# Altium schematic sheet Sheet1.SchDoc
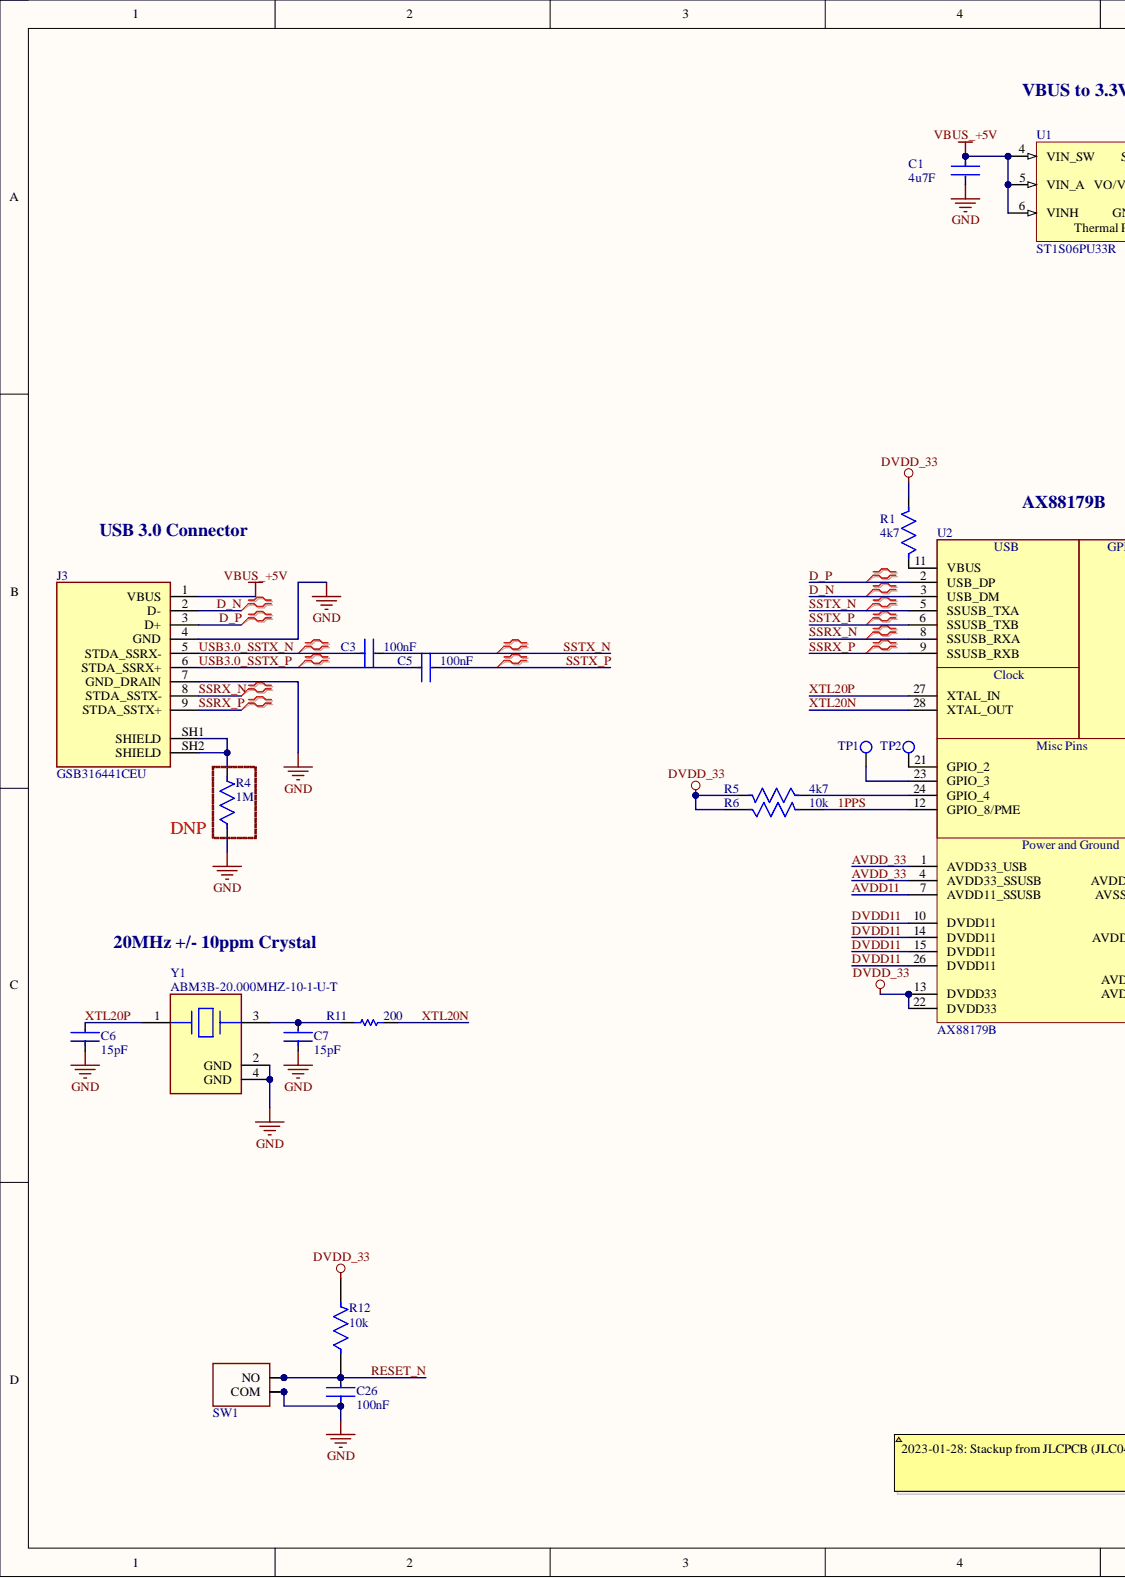
[diagram p0: Sheet1.SchDoc, left]
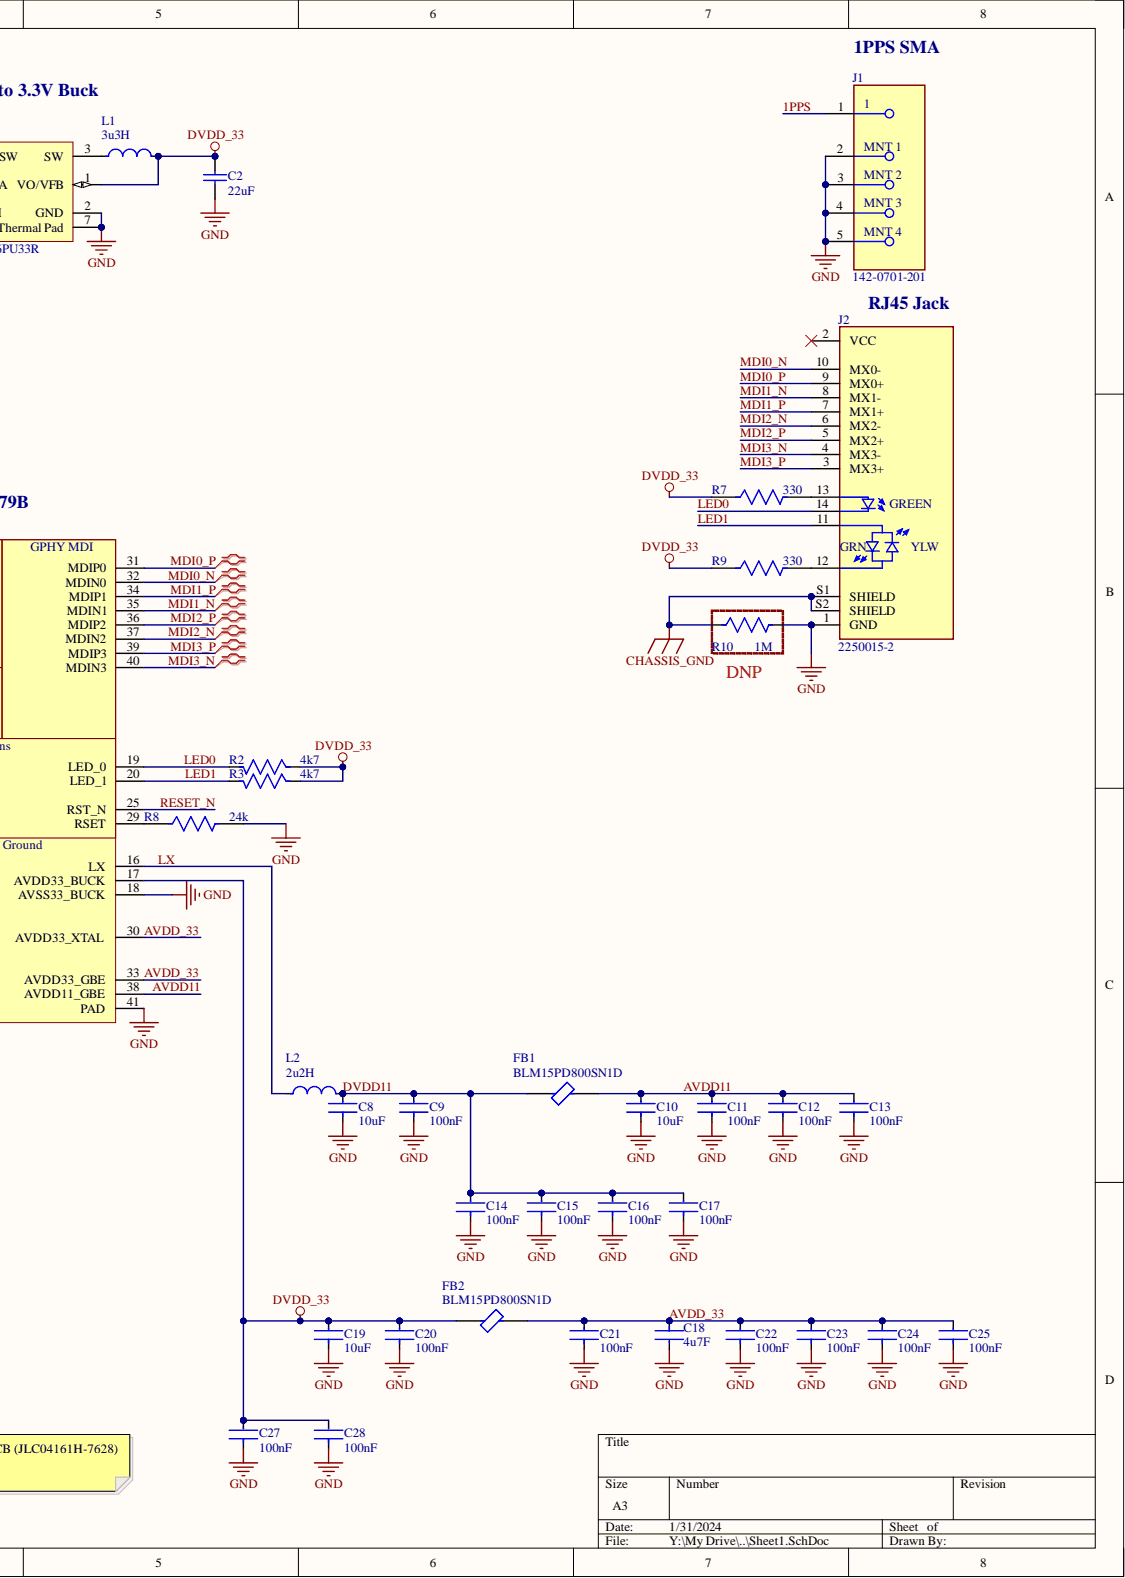
[diagram p1: Sheet1.SchDoc, right]

## Parts
C1  4u7F  [FP-0402-L_1_0_0_15-W_0_5-IPC_C]
C2  22uF  [CAPC1608X100X20ML10]
C3  100nF  [FP-CL251-IPC_C]
C5  100nF  [FP-CL251-IPC_C]
C6  15pF  [FP-CBR04C-MFG]
C7  15pF  [FP-CBR04C-MFG]
C8  10uF  [FP-CL05A106MP5NUNC-MFG]
C9  100nF  [FP-CL251-IPC_C]
C10  10uF  [FP-CL05A106MP5NUNC-MFG]
C11  100nF  [FP-CL251-IPC_C]
C12  100nF  [FP-CL251-IPC_C]
C13  100nF  [FP-CL251-IPC_C]
C14  100nF  [FP-CL251-IPC_C]
C15  100nF  [FP-CL251-IPC_C]
C16  100nF  [FP-CL251-IPC_C]
C17  100nF  [FP-CL251-IPC_C]
C18  4u7F  [FP-0402-L_1_0_0_15-W_0_5-IPC_C]
C19  10uF  [FP-CL05A106MP5NUNC-MFG]
C20  100nF  [FP-CL251-IPC_C]
C21  100nF  [FP-CL251-IPC_C]
C22  100nF  [FP-CL251-IPC_C]
C23  100nF  [FP-CL251-IPC_C]
C24  100nF  [FP-CL251-IPC_C]
C25  100nF  [FP-CL251-IPC_C]
C26  100nF  [FP-CL251-IPC_C]
C27  100nF  [FP-CL251-IPC_C]
C28  100nF  [FP-CL251-IPC_C]
FB1  BLM15PD800SN1D  [FP-BLM15-0_05-MFG]
FB2  BLM15PD800SN1D  [FP-BLM15-0_05-MFG]
J1  142-0701-201  Case/Package: SMA  [FP-142-0701-201-MFG]
J2  2250015-2  Connector Type: RJ45  [CONN_2250015-2]
J3  GSB316441CEU  [CONN_AMPHENOL_GSB316441CEU]
L1  3u3H  [FP-LQM2HPN_G0-IPC_A]
L2  2u2H  [FP-MLZ2012-0_85-IPC_A]
R1  4k7  [FP-RT0402-MFG]
R2  4k7  [FP-RT0402-MFG]
R3  4k7  [FP-RT0402-MFG]
R4  1M  [FP-RMCF0402-MFG]  (no BOM)
R5  4k7  [FP-RT0402-MFG]
R6  10k  [FP-RMCF0402-IPC_C]
R7  330  [FP-RC1005-IPC_B]
R8  24k  [FP-RT0402-MFG]
R9  330  [FP-RC1005-IPC_B]
R10  1M  [FP-RMCF0402-MFG]  (no BOM)
R11  200  [RESC1005X40X25LL10T10]
R12  10k  [FP-RMCF0402-IPC_C]
SW1  SW_PTS815_SJG_250_SMTR_LFS  [SW_PTS815SJG250SMTRLFS]  (value not drawn)
TP1  Test Point 1MM  [TP_PAD-1MM]  (value not drawn)  (no BOM)
TP2  Test Point 1MM  [TP_PAD-1MM]  (value not drawn)  (no BOM)
U1  ST1S06PU33R  [DFN6D_L]
U2  AX88179B  [IC_AX88179B_QFN40_5X5]
Y1  ABM3B-20.000MHZ-10-1-U-T  [FP-ABM3B-MFG]

## Nets
CHASSIS_GND: J2.S1(SHIELD) J2.S2(SHIELD) R10.1
DVDD_33: C2.2 C19.2 C20.2 C27.2 C28.2 FB2.1 L1.2 R1.2 R2.1 R3.1 R5.2 R6.1 R7.1 R9.1 R12.2 U1.1(VO/VFB) U2.13(DVDD33) U2.17(AVDD33_BUCK) U2.22(DVDD33)
GND: C1.1 C2.1 C6.2 C7.2 C8.1 C9.1 C10.1 C11.1 C12.1 C13.1 C14.1 C15.1 C16.1 C17.1 C18.1 C19.1 C20.1 C21.1 C22.1 C23.1 C24.1 C25.1 C26.1 C27.1 C28.1 J1.2 J1.3 J1.4 J1.5 J2.1(GND) J3.4(GND) J3.7(GND_DRAIN) R4.1 R8.2 R10.2 SW1.1(COM) SW1.2 U1.2(GND) U1.7(Thermal_Pad) U2.18(AVSS33_BUCK) U2.41(PAD) Y1.2(GND) Y1.4(GND)
VBUS_+5V: C1.2 J3.1(VBUS) U1.4(VIN_SW) U1.5(VIN_A) U1.6(VINH)
1PPS: J1.1 R6.2 U2.12(GPIO_8/PME)
AVDD11: C10.2 C11.2 C12.2 C13.2 FB1.2 U2.7(AVDD11_SSUSB) U2.38(AVDD11_GBE)
AVDD_33: C18.2 C21.2 C22.2 C23.2 C24.2 C25.2 FB2.2 U2.1(AVDD33_USB) U2.4(AVDD33_SSUSB) U2.30(AVDD33_XTAL) U2.33(AVDD33_GBE)
D_N: J3.2(D-) U2.3(USB_DM)
D_P: J3.3(D+) U2.2(USB_DP)
DVDD11: C8.2 C9.2 C14.2 C15.2 C16.2 C17.2 FB1.1 L2.2 U2.10(DVDD11) U2.14(DVDD11) U2.15(DVDD11) U2.26(DVDD11)
LED0: J2.14 R2.2 U2.19(LED_0)
LED1: J2.11 R3.2 U2.20(LED_1)
LX: L2.1 U2.16(LX)
MDI0_N: J2.10(MX0-) U2.32(MDIN0)
MDI0_P: J2.9(MX0+) U2.31(MDIP0)
MDI1_N: J2.8(MX1-) U2.35(MDIN1)
MDI1_P: J2.7(MX1+) U2.34(MDIP1)
MDI2_N: J2.6(MX2-) U2.37(MDIN2)
MDI2_P: J2.5(MX2+) U2.36(MDIP2)
MDI3_N: J2.4(MX3-) U2.40(MDIN3)
MDI3_P: J2.3(MX3+) U2.39(MDIP3)
RESET_N: C26.2 R12.1 SW1.3(NO) SW1.4 U2.25(RST_N)
SSRX_N: J3.8(STDA_SSTX-) U2.8(SSUSB_RXA)
SSRX_P: J3.9(STDA_SSTX+) U2.9(SSUSB_RXB)
SSTX_N: C3.2 U2.5(SSUSB_TXA)
SSTX_P: C5.2 U2.6(SSUSB_TXB)
USB3.0_SSTX_N: C3.1 J3.5(STDA_SSRX-)
USB3.0_SSTX_P: C5.1 J3.6(STDA_SSRX+)
XTL20N: R11.2 U2.28(XTAL_OUT)
XTL20P: C6.1 U2.27(XTAL_IN) Y1.1
NetC7_1: C7.1 R11.1 Y1.3
NetJ2_12: J2.12 R9.2
NetJ2_13: J2.13 R7.2
NetJ3_SH1: J3.SH1(SHIELD) J3.SH2(SHIELD) R4.2
NetL1_1: L1.1 U1.3(SW)
NetR1_1: R1.1 U2.11(VBUS)
NetR5_1: R5.1 U2.24(GPIO_4)
NetR8_1: R8.1 U2.29(RSET)
NetTP1_1: TP1.1 U2.23(GPIO_3)
NetTP2_1: TP2.1 U2.21(GPIO_2)

Not connected: J2 2(VCC)

## Library data of the parts
C1 C18 = CMP-14477-004104-2: Ceramic Capacitor General Use 4.7uF ±20% 10V X5R 0402; Manufacturer: Taiyo Yuden; Manufacturer Part Number: LMK105BBJ475MVLF; Material: 4241ConductorMaterial; Capacitance: 4.7uF; Case Code (Imperial): 0402; Case Code (Metric): 1005; Case/Package: 0402; Category: Ceramic Capacitors; Component Kind: Standard; ComponentLink1Description: https://datasheet.ciiva.com/24462/423lmk105bbj475mvlf-24462535.pdf?src-supplier=Onlinecomponents.com; ComponentLink1URL: https://datasheet.ciiva.com/24462/423lmk105bbj475mvlf-24462535.pdf?src-supplier=Onlinecomponents.com; ComponentLink2Description: https://datasheet.ciiva.com/18697/mlcc-all-e-18697686.pdf?src-supplier=Digikey; ComponentLink2URL: https://datasheet.ciiva.com/18697/mlcc-all-e-18697686.pdf?src-supplier=Digikey; ComponentLink3Description: https://datasheet.ciiva.com/18697/mlcc-all-e-18697685.pdf?src-supplier=Digikey; ComponentLink3URL: https://datasheet.ciiva.com/18697/mlcc-all-e-18697685.pdf?src-supplier=Digikey; Construction: Flat; Dielectric: X5R; Halogen Free: Halogen Free; Height: 0.5mm; Length: 1mm; Library Name: Altium Content Vault; Max Operating Temperature: 85°C; Min Operating Temperature: -55°C; Mount: Surface Mount; Mounting Technology: Surface Mount; Package Quantity: 10000; Packaging: Tape and Reel; Pricing: 1=0.19, 10=0.12, 50=0.076, 100=0.0607, 500=0.04386, 1000=0.03879, 2500=0.03711, 5000=0.03373 (USD); Resistor Type: Ceramic; RoHS: Yes; RoHS Compliant: Yes; Series: M; Stock: 753964; Supplier: Digikey; Supplier Part Number: 587-3408-1-ND; Termination: SMD/SMT; Thickness: 0.022inch; Tolerance: 20%; Value: 4.7uF; Voltage Rating: 10V; Voltage Rating (DC): 10V; Weight: 2.3E-05oz; Width: 0.02inch
C2 = CMP-2000-05441-1: Chip Capacitor, 22 uF, +/- 20%, 10 V, -55 to 85 degC, 0603 (1608 Metric), RoHS, Tape and Reel; Manufacturer: TDK; Manufacturer Part Number: C1608X5R1A226M080AC; PCN Part Number: MLCCPartNumberChange30/Nov/2012; Capacitance: 22µF; Design Resources: 20WNon-IsolatedBuckLEDDriverUsingPLLNK460KG; Dielectric: X5R; Dielectric Material: Ceramic; Kits: 445-9014-KIT-ND-KITMLCC100PF-22UF060310-50V; Material: 4241ConductorMaterial; Online Catalog: CSeries,GeneralPurpose; Other Names: 445-9077-1; Product Training Modules: CSeriesGeneralApplicationsSEAT,CCV,andTVCLDesignToolsGuidetoReplacinganElectrolyticCapacitorwithanMLCC; Voltage - Rated: 10V; Applications: GeneralPurpose; Case Code (Imperial): 0603; Case Code (Metric): 1608; Case/Package: 0603; Category: Capacitors; Component Kind: Standard; ComponentLink1Description: https://datasheet.ciiva.com/6274/tdkmlcccaprange-6274860.pdf?src-supplier=Digikey; ComponentLink1URL: https://datasheet.ciiva.com/6274/tdkmlcccaprange-6274860.pdf?src-supplier=Digikey; ComponentLink2Description: https://datasheet.ciiva.com/6274/tdkmlcccaprange-6274859.pdf?src-supplier=Digikey; ComponentLink2URL: https://datasheet.ciiva.com/6274/tdkmlcccaprange-6274859.pdf?src-supplier=Digikey; ComponentLink3Description: https://datasheet.ciiva.com/6274/tdkmlcccaprange-6274861.pdf?src-supplier=Digikey; ComponentLink3URL: https://datasheet.ciiva.com/6274/tdkmlcccaprange-6274861.pdf?src-supplier=Digikey; ComponentLink4Description: https://datasheet.ciiva.com/24216/mlcc-commercial-general-en-24216575.pdf?src-supplier=Mouser; ComponentLink4URL: https://datasheet.ciiva.com/24216/mlcc-commercial-general-en-24216575.pdf?src-supplier=Mouser; Datasheet URL: http://download.siliconexpert.com/pdfs/2015/4/21/15/54/45/779/tdk_/manual/37024850441143360mlcc_commercial_general_en.pdf; Datasheet Version: Mar-15; Family: CeramicCapacitors; Features: -; Height: 0.8mm; Height - Seated (Max): -; Lead Free: Lead Free; Lead Spacing: -; Lead Style: -; Length: 1.6mm; Library Name: Altium Content Vault; Manufacturer URL: http://www.tdk.com/; Max Operating Temperature: 85°C; Min Operating Temperature: -55°C; Mounting Technology: Surface Mount; Mounting Type: SurfaceMount,MLCC; Operating Temperature: -55degC~85degC; Package / Case: 0603(1608Metric); Package Description: 2-Pin Surface Mount Device, Body 1.6 x 0.8 mm; Package Quantity: 4000; Package Reference: 603; Packaging: CutTape(CT); Pricing: 1=0.33, 10=0.219, 50=0.1612, 100=0.1312, 500=0.1036, 1000=0.08748 (USD); Ratings: -; Reach SVHC Compliant: No; RoHS: Yes; RoHS Compliant: Yes; RoHS Information: RoHSCertificate-MLCC; Series: C; Size / Dimension: 0.063"Lx0.031"W(1.60mmx0.80mm); Standard Package: 1; Stock: 1433584; Supplier: Digikey; Supplier Part Number: 445-9077-1-ND; Temperature Coefficient: X5R; Termination: SMD/SMT; Thickness (Max): 0.035"(0.90mm); Tolerance: ±20%; Value: 22uF; Voltage Rating: 10V; Voltage Rating (DC): 10V; Weight: 7.1E-05oz; Width: 0.8mm
C3 C5 C9 C11-C17 C20-C28 = CMP-13271-002758-1: Cap Ceramic 100nF 10V X7R ±10% SMD 0402 +125°C Paper T/R; Manufacturer: KEMET; Manufacturer Part Number: C0402C104K4RAC-TU; Dissipation Factor: 3.5%; Voltage: 16V; Capacitance: 100nF; Case Code (Imperial): 0402; Case Code (Metric): 1005; Case/Package: 0402; Category: Ceramic Capacitors; Component Kind: Standard; Depth: 0.5mm; Dielectric: X7R; Dielectric Material: Ceramic; Height: 0.5mm; Length: 1mm; Library Name: Altium Content Vault; Max Operating Temperature: 125°C; Min Operating Temperature: -55°C; Mount: Surface Mount; Package Quantity: 50000; Packaging: Tape and Reel; Pins: 2; Pricing: 1=0.1, 10=0.032, 50=0.0182, 100=0.0141, 500=0.0106, 1000=0.00833, 2500=0.00758, 5000=0.00697 (USD); Radiation Hardening: No; Reach SVHC Compliant: No; RoHS: Yes; Series: C; Stock: 3965396; Supplier: Digikey; Supplier Part Number: 399-C0402C104K4RAC7867CT-ND; Termination: SMD/SMT; Thickness: 0.022inch; Tolerance: 10%; Value: 100nF; Voltage Rating: 10V; Voltage Rating (DC): 10V; Width: 0.02inch
C6 C7 = CMP-03020-019954-1: CAP CER 15PF 100V C0G/NP0 0402; Manufacturer: KEMET; Manufacturer Part Number: CBR04C150F1GAC; Material: Ceramic; Temperature Characteristic: C0G; Temperature Coefficient: 30ppm/°C; Tolerance: 0.01; Automotive: No; Capacitance: 15 pF; Category: Cap; Component Kind: Standard; ComponentLink2Description: Datasheet; ComponentLink2URL: https://content.kemet.com/datasheets/KEM_C1030_CBR_SMD.pdf; Device Class L1: Passive Components; Device Class L2: Capacitors; Device Class L3: Ceramic Capacitors; Digikey Description: CAP CER 15PF 100V C0G/NP0 0402; Height: 0.55mm; Ipc Land Pattern Name: CAPC10050X50; Lead Free: Yes; Library Name: Altium Content Vault; Mouser Description: Multilayer Ceramic Capacitors MLCC - SMD/SMT 100volts 15pF C0G 0402 1% Tol RF; Octopart: https://octopart.com/cbr04c150f1gac-kemet-30609229; Package: CBR04C; RoHS: TRUE; Temperature: -55°C to +125°C; Temperature Range High: +125°C; Temperature Range Low: -55°C; Value: 15 pF; Voltage Rating: 100 V
C8 C10 C19 = CMP-13271-000002-1: CAP CER 10UF 10V X5R 0402; Manufacturer: Samsung; Manufacturer Part Number: CL05A106MP5NUNC; Material: Ceramic; Temperature Coefficient: 15%; Tolerance: 20%; Automotive: No; Capacitance: 10uF; Category: Cap; Component Kind: Standard; ComponentLink2Description: Datasheet; ComponentLink2URL: http://www.samsungsem.com/kr/support/product-search/mlcc/__icsFiles/afieldfile/2019/05/10/19-CL05A106MP5NUNC.pdf; Device Class L1: Passive Components; Device Class L2: Capacitors; Device Class L3: Ceramic Capacitors; Digikey Description: CAP CER 10UF 10V X5R 0402; Height: 0.7mm; Ipc Land Pattern Name: CAPC10050X50; Lead Free: Yes; Library Name: Altium Content Vault; Octopart: https://octopart.com/cl05a106mp5nunc-samsung-22457395; Package: CL05A106MP5NUNC; RoHS: TRUE; Temperature: -55°C to +85°C; Temperature Characteristic: X5R; Temperature Range High: +85°C; Temperature Range Low: -55°C; Value: 10uF; Voltage Rating: 10V
FB1 FB2 = CMP-0686-00284-4: Chip Ferrite Bead, 0402, 80Ω @ 100MHz, 0.07Ω, 25%, 1.5A; Manufacturer: Murata; DC Resistance (DCR): 70mR; Impedance: 80R; Number of Circuits: 1; Case Code (Imperial): 0402; Case Code (Metric): 1005; Case/Package: 0402; Category: Ferrite Beads and Chips; Component Kind: Standard; ComponentLink1Description: https://datasheet.ciiva.com/41449/001172208-da-01-en-drossel-0402-80r-1-0x0-5mm-10000st-41449497.pdf?src-supplier=Conrad; ComponentLink1URL: https://datasheet.ciiva.com/41449/001172208-da-01-en-drossel-0402-80r-1-0x0-5mm-10000st-41449497.pdf?src-supplier=Conrad; ComponentLink2Description: https://datasheet.ciiva.com/1012/l0110e-1012441.pdf?src-supplier=Digikey; ComponentLink2URL: https://datasheet.ciiva.com/1012/l0110e-1012441.pdf?src-supplier=Digikey; ComponentLink3Description: https://datasheet.ciiva.com/1012/l0110e-1012440.pdf?src-supplier=Digikey; ComponentLink3URL: https://datasheet.ciiva.com/1012/l0110e-1012440.pdf?src-supplier=Digikey; ComponentLink4Description: https://datasheet.ciiva.com/1012/l0110e-1012442.pdf?src-supplier=Digikey; ComponentLink4URL: https://datasheet.ciiva.com/1012/l0110e-1012442.pdf?src-supplier=Digikey; ComponentLink5Description: https://datasheet.ciiva.com/16420/l0110s0100blm15pgblm15pd-16420757.pdf?src-supplier=Future+Electronics; ComponentLink5URL: https://datasheet.ciiva.com/16420/l0110s0100blm15pgblm15pd-16420757.pdf?src-supplier=Future+Electronics; Current Rating: 1.5A; DC Resistance-Max: 70mR; Depth: 0.5mm; Height: 0.5mm; Lead Free: Lead Free; Length: 1mm; Library Name: Altium Content Vault; Manufacturer Part Number: BLM15PD800SN1D; Material: Ferrite; Max Current Rating: 1.5A; Max DC Current: 1.5A; Max Operating Temperature: 125°C; Min Operating Temperature: -55°C; Number of Lines: 1; Number of Pins: 2; Package Quantity: 1; Packaging: Tape and Reel; Pins: 2; Pricing: 1=0.1, 10=0.068, 25=0.0616, 50=0.0556, 100=0.0408, 250=0.02968, 500=0.0272, 1000=0.02348, 5000=0.01854 (USD); REACH SVHC: No SVHC; Reach SVHC Compliant: No; RoHS: Yes; RoHS Compliant: Yes; Series: BLM15PD; Stock: 94761; Supplier: Digikey; Supplier Part Number: 490-5202-1-ND; Termination: SMD/SMT; Test Frequency: 100MHz; Tolerance: 25%; Width: 0.5mm
J1 = CMP-09588-000003-1: CONN SMA JACK STR 50 OHM PCB; Manufacturer: Bel Cinch; Body Plating: Gold; Clock Frequency: 18GHz; Gender: Male; Impedance: 50R; Operating Frequency: 0 to 18 GHz; Voltage Rating: 335V; Category: RF / Coaxial Connectors; Component Kind: Standard; ComponentLink1Description: https://datasheet.ciiva.com/643/dr-1420701206-643288.pdf?src-supplier=Digikey; ComponentLink1URL: https://datasheet.ciiva.com/643/dr-1420701206-643288.pdf?src-supplier=Digikey; ComponentLink2Description: https://datasheet.ciiva.com/23769/pi-1420701201-23769366.pdf?src-supplier=Newark; ComponentLink2URL: https://datasheet.ciiva.com/23769/pi-1420701201-23769366.pdf?src-supplier=Newark; ComponentLink3Description: https://datasheet.ciiva.com/3131/pi-142-0701-201-3131251.pdf?src-supplier=Avnet; ComponentLink3URL: https://datasheet.ciiva.com/3131/pi-142-0701-201-3131251.pdf?src-supplier=Avnet; ComponentLink4Description: https://datasheet.ciiva.com/16776/142-0701-201-16776554.pdf?src-supplier=Future+Electronics; ComponentLink4URL: https://datasheet.ciiva.com/16776/142-0701-201-16776554.pdf?src-supplier=Future+Electronics; ComponentLink5Description: https://datasheet.ciiva.com/30080/pi-142-0701-201-30080563.pdf?src-supplier=Onlinecomponents.com; ComponentLink5URL: https://datasheet.ciiva.com/30080/pi-142-0701-201-30080563.pdf?src-supplier=Onlinecomponents.com; Connector Type: SMA; Contact Material: Copper; Contact Plating: Gold; Fastening Type: Threaded; Frequency: 18GHz; Library Name: Altium Content Vault; Manufacturer Part Number: 142-0701-201; Max Frequency: 18GHz; Max Operating Temperature: 165°C; Min Operating Temperature: -65°C; Mount: Through Hole; Mounting Technology: Through Hole; Orientation: Straight; Package Quantity: 5; Packaging: Bulk; Pricing: 1=4.03, 10=3.663, 25=3.4692, 50=3.3884, 100=3.2271, 250=2.82372, 500=2.74304, 1000=2.33966, 2500=2.1783 (USD); Radiation Hardening: No; Reach SVHC Compliant: Unknown; RoHS: Yes; RoHS Compliant: Yes; Series: SMA; Stock: 9658; Supplier: Digikey; Supplier Part Number: J500-ND; Termination: Solder
J2 = CONN_2250015-2: Modular Connectors / Ethernet Connectors 1X1 MAG45 GIG 7G140ET TAB W/ LEDS; Manufacturer: Bel; Direction: Up; MF: TRP Connector; Number of Cores per Jack: 8; Number of Ports: 1; Shielding: Shielded; Availability: Warning; Category: Modular / Ethernet Connectors; Check_prices: https://www.snapeda.com/parts/2250015-2/TRP%20Connector/view-part/4423750/?ref=eda; Color: Green,Yellow; Component Kind: Standard; ComponentLink1Description: http://belfuse.com/resources/brochures/magneticsolutions/br-mag-magjack-icm.pdf?src-supplier=Digikey; ComponentLink1URL: http://belfuse.com/resources/brochures/magneticsolutions/br-mag-magjack-icm.pdf?src-supplier=Digikey; ComponentLink2Description: https://datasheet.octopart.com/2250015-2-Bel-Fuse-datasheet-137332709.pdf?src-supplier=Sager+Electronics; ComponentLink2URL: https://datasheet.octopart.com/2250015-2-Bel-Fuse-datasheet-137332709.pdf?src-supplier=Sager+Electronics; Contact Material: Bronze; Contact Plating: Gold; Housing Material: Thermoplastic; Manufacturer Part Number: 2250015-2; Max Operating Temperature: 85°C; Min Operating Temperature: -40°C; Mounting Technology: Through Hole; MP: 2250015-2; Number of Rows: 1; Package: None; Packaging: Tray; Price: None; Pricing: 1=10.04, 10=9.04, 50=7.55, 100=7.41, 1000=6.08, 10000=5.8 (USD); Purchase-URL: https://pricing.snapeda.com/search/part/2250015-2/?ref=eda; RoHS: Yes; RoHS Compliant: Yes; Series: MagJack®; SnapEDA_Link: https://www.snapeda.com/parts/2250015-2/TRP%20Connector/view-part/4423750/?ref=snap; Stock: 1265; Supplier: Mouser; Supplier Part Number: 530-2250015-2; Termination: Solder; Library Name: LotusSound.IntLib
J3 = CONN_GSB316441CEU: Conn USB 3.0 Type A PL 9 POS Solder RA SMD 9 Terminal 1 Port; Manufacturer: Amphenol Commercial; Current Rating: 1.8A; MF: Amphenol; Number of Contacts: 9; Category: USB Connectors; Check_prices: https://www.snapeda.com/parts/GSB316441CEU/Amphenol/view-part/?ref=eda; Component Kind: Standard; ComponentLink1Description: https://datasheet.ciiva.com/10945/gsb316441ceu-10945197.pdf?src-supplier=Avnet; ComponentLink1URL: https://datasheet.ciiva.com/10945/gsb316441ceu-10945197.pdf?src-supplier=Avnet; Connector Type: USB; Contact Material: Copper Alloy; Contact Plating: Gold; Manufacturer Part Number: GSB316441CEU; Mounting Technology: Surface Mount,Horizontal; MP: GSB316441CEU; Orientation: Right Angle; Package: None; Package Quantity: 1; Packaging: Tape and Reel; Pricing: 1=2.04, 10=1.775, 25=1.6996, 50=1.6618, 100=1.5863, 250=1.43524 (USD); Radiation Hardening: No; RoHS: Yes; RoHS Compliant: Yes; Series: GSB3; SnapEDA_Link: https://www.snapeda.com/parts/GSB316441CEU/Amphenol/view-part/?ref=snap; Stock: 13312; Supplier: Digikey; Supplier 1: Digikey; Supplier Part Number: GSB316441CEUCT-ND; Supplier Part Number 1: GSB316441CEUCT-ND; Termination: SMD/SMT; Library Name: LotusSound.IntLib
L1 = CMP-06042-016211-1: Multilayer Type Inductor for Power Lines, 1008 (2520), 3.3μH, 20%, 0.125Ω, 1.2A; Manufacturer: Murata; Manufacturer Part Number: LQM2HPN3R3MG0L; Core Material: Ferrite; DC Resistance (DCR): 125mR; Inductance: 3.3uH; Self Resonant Frequency: 30MHz; Shielding: Shielded; Test Frequency: 1MHz; Value: 3.3uH; Case Code (Imperial): 1008; Case Code (Metric): 2520; Case/Package: 1008; Category: Fixed Inductors; Component Kind: Standard; ComponentLink1Description: https://datasheet.ciiva.com/30490/0900766b812561d9-30490184.pdf?src-supplier=Rs; ComponentLink1URL: https://datasheet.ciiva.com/30490/0900766b812561d9-30490184.pdf?src-supplier=Rs; ComponentLink2Description: https://datasheet.ciiva.com/1042/l0075s0104lqm2hp-g0-1042940.pdf?src-supplier=Digikey; ComponentLink2URL: https://datasheet.ciiva.com/1042/l0075s0104lqm2hp-g0-1042940.pdf?src-supplier=Digikey; ComponentLink3Description: https://datasheet.ciiva.com/1042/l0075s0104lqm2hp-g0-1042941.pdf?src-supplier=Digikey; ComponentLink3URL: https://datasheet.ciiva.com/1042/l0075s0104lqm2hp-g0-1042941.pdf?src-supplier=Digikey; ComponentLink4Description: https://datasheet.ciiva.com/1042/l0075s0104lqm2hp-g0-1042942.pdf?src-supplier=Digikey; ComponentLink4URL: https://datasheet.ciiva.com/1042/l0075s0104lqm2hp-g0-1042942.pdf?src-supplier=Digikey; ComponentLink5Description: https://datasheet.ciiva.com/8509/l0075s0104lqm2hp-g0-8509087.pdf?src-supplier=Avnet; ComponentLink5URL: https://datasheet.ciiva.com/8509/l0075s0104lqm2hp-g0-8509087.pdf?src-supplier=Avnet; Current Rating: 1.2A; DC Current: 1.2A; Depth: 2.2mm; Height: 0.9mm; Height - Seated (Max): 0.039inch; Inductance Tolerance: 20%; Lead Free: Lead Free; Length: 2.5mm; Library Name: Altium Content Vault; Max DC Current: 1.2A; Max Operating Temperature: 125°C; Military Standard: Not; Min Operating Temperature: -55°C; Mount: Surface Mount; Mounting Technology: Surface Mount; Package Quantity: 3000; Packaging: Tape and Reel; Pricing: 1=0.21, 10=0.171, 25=0.1576, 50=0.1444, 100=0.1274, 250=0.12344, 500=0.11032, 1000=0.0893 (USD); Radiation Hardening: No; REACH SVHC: No SVHC; Reach SVHC Compliant: No; RoHS: Yes; RoHS Compliant: Yes; Series: LQM2HP_G0; Stock: 32510; Supplier: Digikey; Supplier Part Number: 490-5115-1-ND; Termination: SMD/SMT; Tolerance: 20%; Width: 2mm
L2 = CMP-08253-000395-1: Shielded Multilayer Inductor 2.2uH ±20% 800mA 156mΩ SMD 0805; Manufacturer: TDK; Manufacturer Part Number: MLZ2012N2R2LT000; Core Material: Ferrite; DC Resistance (DCR): 156mR; Saturation Current: 170mA; Self Resonant Frequency: 120MHz; Test Frequency: 2MHz; Case Code (Imperial): 0805; Case Code (Metric): 2012; Case/Package: 0805; Category: Fixed Inductors; Component Kind: Standard; ComponentLink1Description: https://datasheet.ciiva.com/29698/inductor-commercial-decoupling-mlz-en-29698919.pdf?src-supplier=Digikey; ComponentLink1URL: https://datasheet.ciiva.com/29698/inductor-commercial-decoupling-mlz-en-29698919.pdf?src-supplier=Digikey; ComponentLink2Description: https://datasheet.ciiva.com/29698/inductor-commercial-decoupling-mlz-en-29698920.pdf?src-supplier=Digikey; ComponentLink2URL: https://datasheet.ciiva.com/29698/inductor-commercial-decoupling-mlz-en-29698920.pdf?src-supplier=Digikey; ComponentLink3Description: https://datasheet.ciiva.com/29698/inductor-commercial-decoupling-mlz-en-29698918.pdf?src-supplier=Digikey; ComponentLink3URL: https://datasheet.ciiva.com/29698/inductor-commercial-decoupling-mlz-en-29698918.pdf?src-supplier=Digikey; Current Rating: 800mA; Height - Seated (Max): 0.041inch; Inductance: 2.2uH; Length: 0.079inch; Library Name: Altium Content Vault; Max Operating Temperature: 125°C; Min Operating Temperature: -55°C; Mount: Surface Mount; Mounting Technology: Surface Mount; Package Quantity: 1; Packaging: Tape and Reel; Pricing: 1=0.12, 10=0.095, 25=0.0876, 50=0.0772, 100=0.0676, 250=0.06436, 500=0.06052, 1000=0.04635 (USD); RoHS: Yes; RoHS Compliant: Yes; Series: MLZ; Shielding: Shielded; Stock: 32581; Supplier: Digikey; Supplier Part Number: 445-6758-1-ND; Tolerance: 20%; Value: 2.2uH; Width: 0.049inch
R1-R3 R5 = CMP-2002-02814-2: RES SMD 4.7K OHM 0.1% 1/16W 0402; Manufacturer: Yageo; Manufacturer Part Number: RT0402BRD074K7L; Power Rating: 0.063W; Resistance: 4.7KΩ; Temperature Coefficient: 25 ppm/°C; Tolerance: 0.001; Value: 4.7KΩ; Voltage Rating: 50V; Automotive: No; Category: Res; Component Kind: Standard; ComponentLink2Description: Datasheet; ComponentLink2URL: http://www.yageo.com/documents/recent/PYu-RT_1-to-0.01_RoHS_L_9.pdf; Composition: Thin Film; Device Class L1: Passive Components; Device Class L2: Resistors; Device Class L3: Chip SMD Resistors; Digikey Description: RES SMD 4.7K OHM 0.1% 1/16W 0402; Footprint Url: http://www.yageo.com/exep/pages/download/literatures/PYu-R_Mount_10.pdf; Height: 0.35mm; Ipc Land Pattern Name: RESC10050X30; Lead Free: Yes; Library Name: Altium Content Vault; Mouser Description: Thin Film Resistors 1/16W 4.7K ohm .1% 25ppm; Octopart: https://octopart.com/rt0402brd074k7l-yageo-1415343; Package: RT0402; RoHS: TRUE; Series: RT; Temperature: -55°C to +155°C; Temperature Range High: +155°C; Temperature Range Low: -55°C
R4 R10 = CMP-26527-001513-1: 1MΩ ±5% 0.063W 0402 Thick Film Chip Resistor AEC-Q200 compliant; Manufacturer: Stackpole Electronics; Manufacturer Part Number: RMCF0402JT1M00; Max Power Dissipation: 63mW; Resistance: 1MR; Temperature Coefficient: 100ppm/°C; Value: 1MR; Working Voltage: 50V; Case Code (Imperial): 0402; Case Code (Metric): 1005; Case/Package: 0402; Category: Chip SMD Resistors; ComponentLink1Description: https://datasheet.ciiva.com/26946/getdatasheetpartid-791049-26946706.pdf?src-supplier=Verical; ComponentLink1URL: https://datasheet.ciiva.com/26946/getdatasheetpartid-791049-26946706.pdf?src-supplier=Verical; Construction: Rectangular; Contact Plating: Tin; Depth: 0.5mm; Features: Automotive AEC-Q200; Height: 0.016inch; Lead Free: Lead Free; Length: 0.039inch; Library Name: Altium Content Vault; Max Operating Temperature: 155°C; Military Standard: Not; Min Operating Temperature: -55°C; Mount: Surface Mount; Mounting Technology: Surface Mount; Number of Terminations: 2; Packaging: Tape and Reel; Power Rating: 63mW; Pricing: 1=0.1, 10=0.016, 50=0.009, 100=0.0072, 500=0.00462, 1000=0.00389, 5000=0.00269 (USD); Radiation Hardening: No; Resistor Type: Thick Film; RoHS: Yes; RoHS Compliant: Yes; Series: RMCF; Stock: 22890; Supplier: Digikey; Supplier Part Number: RMCF0402JT1M00CT-ND; Technology: THICK FILM; Tolerance: 5%; Width: 0.02inch
R6 R12 = CMP-26527-000128-1: 10kΩ ±1% 0.063W 0402 Thick Film Chip Resistor AEC-Q200 compliant; Manufacturer: Stackpole Electronics; Manufacturer Part Number: RMCF0402FT10K0; Max Power Dissipation: 63mW; Power Rating: 62.5mW; Resistance: 10kR; Temperature Coefficient: 100ppm/°C; Value: 10kR; Case Code (Imperial): 0402; Case Code (Metric): 1005; Case/Package: 0402; Category: Chip SMD Resistors; Component Kind: Standard; ComponentLink1Description: https://datasheet.ciiva.com/24341/sei-rmcp-24341800.pdf?src-supplier=Onlinecomponents.com; ComponentLink1URL: https://datasheet.ciiva.com/24341/sei-rmcp-24341800.pdf?src-supplier=Onlinecomponents.com; Construction: Rectangular; Contact Plating: Tin; Depth: 0.5mm; Features: Automotive AEC-Q200; Height: 0.016inch; Lead Free: Lead Free; Length: 1mm; Library Name: Altium Content Vault; Max Operating Temperature: 155°C; Military Standard: Not; Min Operating Temperature: -55°C; Mount: Surface Mount; Mounting Technology: Surface Mount; Number of Terminations: 2; Packaging: Tape and Reel; Pricing: 1=0.1, 10=0.021, 50=0.0116, 100=0.0093, 500=0.00594, 1000=0.005, 5000=0.00345 (USD); Radiation Hardening: No; Ratings: AEC-Q200; Resistor Type: Thick Film; RoHS: Yes; RoHS Compliant: Yes; Series: RMCF; Size Code: 0402; Stock: 16567212; Supplier: Digikey; Supplier Part Number: RMCF0402FT10K0CT-ND; Tolerance: 1%; Width: 0.02inch
R7 R9 = CMP-13261-004965-1: Res Thick Film 0402 330Ω 1% 0.063W ±100ppm/°C Molded SMD T/R; Manufacturer: Samsung; Manufacturer Part Number: RC1005F331CS; Max Power Dissipation: 63mW; Resistance: 330R; Value: 330R; Case Code (Imperial): 0402; Case Code (Metric): 1005; Case/Package: 0402; Category: Chip SMD Resistors; Component Kind: Standard; ComponentLink1Description: https://datasheet.ciiva.com/16481/rc-series-16481001.pdf?src-supplier=Future+Electronics; ComponentLink1URL: https://datasheet.ciiva.com/16481/rc-series-16481001.pdf?src-supplier=Future+Electronics; Composition: Thick Film; Contact Plating: Tin; Depth: 0.5mm; Features: Moisture Resistant; Height: 0.016inch; Length: 0.039inch; Library Name: Altium Content Vault; Max Operating Temperature: 155°C; Military Standard: Not; Min Operating Temperature: -55°C; Number of Terminations: 2; Package Quantity: 1; Packaging: Tape and Reel; Power Rating: 63mW; Pricing: 1=0.1, 10=0.034, 50=0.0224, 100=0.0188, 500=0.01312, 1000=0.01142, 5000=0.0085 (USD); Radiation Hardening: No; RoHS: Yes; RoHS Compliant: Yes; Series: RC; Stock: 6645; Supplier: Digikey; Supplier Part Number: 1276-3976-1-ND; Temperature Coefficient: 100ppm/°C; Tolerance: 1%; Width: 0.02inch
R8 = CMP-2002-03028-2: RES SMD 24K OHM 1% 1/16W 0402; Manufacturer: Yageo; Manufacturer Part Number: RT0402FRE0724KL; Power Rating: 0.063W; Resistance: 24KΩ; Temperature Coefficient: 50 ppm/°C; Tolerance: 0.01; Value: 24KΩ; Voltage Rating: 50V; Automotive: No; Category: Res; Component Kind: Standard; ComponentLink2Description: Datasheet; ComponentLink2URL: http://www.yageo.com/documents/recent/PYu-RT_1-to-0.01_RoHS_L_9.pdf; Composition: Thin Film; Device Class L1: Passive Components; Device Class L2: Resistors; Device Class L3: Chip SMD Resistors; Digikey Description: RES SMD 24K OHM 1% 1/16W 0402; Footprint Url: http://www.yageo.com/exep/pages/download/literatures/PYu-R_Mount_10.pdf; Height: 0.35mm; Ipc Land Pattern Name: RESC10050X30; Lead Free: Yes; Library Name: Altium Content Vault; Octopart: https://octopart.com/rt0402fre0724kl-yageo-1380387; Package: RT0402; RoHS: TRUE; Series: RT; Temperature: -55°C to +155°C; Temperature Range High: +155°C; Temperature Range Low: -55°C
R11 = CMP-2002-03738-1: Manufacturer: KOA Speer; Manufacturer Part Number: RK73B1ETTP201J; Power Rating: 63mW; Temperature Coefficient: 200ppm/°C; Tolerance: 5%; Value: 200R; Voltage Rating: 50V; Case Code (Imperial): 0402; Case Code (Metric): 1005; Case/Package: 0402; Category: Chip SMD Resistors; Component Kind: Standard; ComponentLink1Description: https://datasheet.ciiva.com/27054/1876901-27054398.pdf?src-supplier=Jameco; ComponentLink1URL: https://datasheet.ciiva.com/27054/1876901-27054398.pdf?src-supplier=Jameco; ComponentLink2Description: https://datasheet.ciiva.com/15202/getdatasheetpartid-170798-15202604.pdf?src-supplier=Verical; ComponentLink2URL: https://datasheet.ciiva.com/15202/getdatasheetpartid-170798-15202604.pdf?src-supplier=Verical; ComponentLink3Description: https://datasheet.ciiva.com/5555/rk73b-5555653.pdf?src-supplier=Mouser; ComponentLink3URL: https://datasheet.ciiva.com/5555/rk73b-5555653.pdf?src-supplier=Mouser; Construction: Rectangular; Depth: 0.5mm; Height: 0.35mm; Lead Free: Lead Free; Length: 1mm; Library Name: Altium Content Vault; Max Operating Temperature: 155°C; Military Standard: MIL-R-55342F; Min Operating Temperature: -55°C; Mounting Technology: Surface Mount; Package Quantity: 10000; Packaging: Tape and Reel; Part Number: RK73B1ETTP201J; Pricing: 1=0.1, 10=0.017, 50=0.0104, 100=0.0087, 500=0.0058, 1000=0.00494, 5000=0.00355 (USD); RoHS: Yes; RoHS Compliant: Yes; Series: RK73B; Stock: 533194; Supplier: Digikey; Supplier Part Number: 2019-RK73B1ETTP201JCT-ND; Technology: THICK FILM; Termination: SMD/SMT; Voltage Rating (DC): 50V; Width: 0.5mm
SW1 = SW_PTS815_SJG_250_SMTR_LFS: Switch Tactile N.O. SPST Button J-Bend 0.05A 12VDC 3.92N SMD T/R; Manufacturer: ITT C&K; Manufacturer Part Number: PTS815SJG250SMTRLFS; Illumination Type: Non-Illuminated; Mechanical Life: 100000Cycles; MF: C&K; MP: PTS815 SJG 250 SMTR LFS; Operating Force: 400gf; Ratings: IP40; Switch Function: Off-Mom; Switch Travel: 0.2mm; Category: Tactile Switches; Check_prices: https://www.snapeda.com/parts/PTS815%20SJG%20250%20SMTR%20LFS/C%2526K/view-part/?ref=eda; Component Kind: Standard; ComponentLink1Description: http://datasheet.octopart.com/PTS815SJG250SMTRLFS-C%26K-Components-datasheet-130077068.pdf?src-supplier=Future+Electronics; ComponentLink1URL: http://datasheet.octopart.com/PTS815SJG250SMTRLFS-C%26K-Components-datasheet-130077068.pdf?src-supplier=Future+Electronics; ComponentLink2Description: http://datasheet.octopart.com/PTS815SJG250SMTRLFS-C%26K-Components-datasheet-130077068.pdf?src-supplier=Farnell; ComponentLink2URL: http://datasheet.octopart.com/PTS815SJG250SMTRLFS-C%26K-Components-datasheet-130077068.pdf?src-supplier=Farnell; ComponentLink3Description: http://datasheet.octopart.com/PTS815SJG250SMTRLFS-C%26K-Components-datasheet-130077068.pdf?src-supplier=Arrow+Electronics; ComponentLink3URL: http://datasheet.octopart.com/PTS815SJG250SMTRLFS-C%26K-Components-datasheet-130077068.pdf?src-supplier=Arrow+Electronics; ComponentLink4Description: http://datasheet.octopart.com/PTS815SJG250SMTRLFS-C%26K-Components-datasheet-130077068.pdf?src-supplier=Mouser; ComponentLink4URL: http://datasheet.octopart.com/PTS815SJG250SMTRLFS-C%26K-Components-datasheet-130077068.pdf?src-supplier=Mouser; ComponentLink5Description: http://datasheet.octopart.com/PTS815SJG250SMTRLFS-C%26K-Components-datasheet-130077068.pdf?src-supplier=Newark; ComponentLink5URL: http://datasheet.octopart.com/PTS815SJG250SMTRLFS-C%26K-Components-datasheet-130077068.pdf?src-supplier=Newark; ComponentLink6Description: http://datasheet.octopart.com/PTS815SJG250SMTRLFS-C%26K-Components-datasheet-130077068.pdf?src-supplier=Avnet; ComponentLink6URL: http://datasheet.octopart.com/PTS815SJG250SMTRLFS-C%26K-Components-datasheet-130077068.pdf?src-supplier=Avnet; ComponentLink7Description: http://datasheet.octopart.com/PTS815SJG250SMTRLFS-C%26K-Components-datasheet-130077068.pdf?src-supplier=Allied+Electronics; ComponentLink7URL: http://datasheet.octopart.com/PTS815SJG250SMTRLFS-C%26K-Components-datasheet-130077068.pdf?src-supplier=Allied+Electronics; ComponentLink8Description: http://datasheet.octopart.com/PTS815SJG250SMTRLFS-C%26K-Components-datasheet-130077068.pdf?src-supplier=Digikey; ComponentLink8URL: http://datasheet.octopart.com/PTS815SJG250SMTRLFS-C%26K-Components-datasheet-130077068.pdf?src-supplier=Digikey; ComponentLink9Description: http://datasheet.octopart.com/PTS815SJG250SMTRLFS-C%26K-Components-datasheet-130077068.pdf?src-supplier=Verical; ComponentLink9URL: http://datasheet.octopart.com/PTS815SJG250SMTRLFS-C%26K-Components-datasheet-130077068.pdf?src-supplier=Verical; ComponentLink10Description: http://datasheet.octopart.com/PTS815SJG250SMTRLFS-C%26K-Components-datasheet-130077068.pdf?src-supplier=Onlinecomponents.com; ComponentLink10URL: http://datasheet.octopart.com/PTS815SJG250SMTRLFS-C%26K-Components-datasheet-130077068.pdf?src-supplier=Onlinecomponents.com; ComponentLink11Description: http://datasheet.octopart.com/PTS815SJG250SMTRLFS-C%26K-Components-datasheet-130077068.pdf?src-supplier=LCSC; ComponentLink11URL: http://datasheet.octopart.com/PTS815SJG250SMTRLFS-C%26K-Components-datasheet-130077068.pdf?src-supplier=LCSC; ComponentLink12Description: http://datasheet.octopart.com/PTS815SJG250SMTRLFS-C%26K-Components-datasheet-130077068.pdf?src-supplier=TTI; ComponentLink12URL: http://datasheet.octopart.com/PTS815SJG250SMTRLFS-C%26K-Components-datasheet-130077068.pdf?src-supplier=TTI; ComponentLink13Description: http://datasheet.octopart.com/PTS815SJG250SMTRLFS-C%26K-Components-datasheet-130077068.pdf?src-supplier=Sager+Electronics; ComponentLink13URL: http://datasheet.octopart.com/PTS815SJG250SMTRLFS-C%26K-Components-datasheet-130077068.pdf?src-supplier=Sager+Electronics; ComponentLink14Description: http://datasheet.octopart.com/PTS815SJG250SMTRLFS-C%26K-Components-datasheet-130077068.pdf?src-supplier=Electro+Sonic; ComponentLink14URL: http://datasheet.octopart.com/PTS815SJG250SMTRLFS-C%26K-Components-datasheet-130077068.pdf?src-supplier=Electro+Sonic; ComponentLink15Description: http://datasheet.octopart.com/PTS815SJG250SMTRLFS-C%26K-Components-datasheet-130077068.pdf?src-supplier=NAC+Semi; ComponentLink15URL: http://datasheet.octopart.com/PTS815SJG250SMTRLFS-C%26K-Components-datasheet-130077068.pdf?src-supplier=NAC+Semi; Max Operating Temperature: 70°C; Min Operating Temperature: -20°C; Mounting Technology: Surface Mount; Package: None; Packaging: Cut Tape; Pricing: 1=0.25, 10=0.246, 25=0.2332, 100=0.2113, 250=0.1926, 500=0.17902, 1000=0.15271 (USD); RoHS: Yes; Series: PTS815; SnapEDA_Link: https://www.snapeda.com/parts/PTS815%20SJG%20250%20SMTR%20LFS/C%2526K/view-part/?ref=snap; Stock: 38609; Supplier: Digikey; Supplier Part Number: CKN12217-1-ND; Throw Configuration: SPST; Library Name: LotusSound.IntLib
TP1 TP2 = TP_PAD-1MM: Library Name: LotusSound.IntLib
U1 = CMP-0240-00158-1: Synchronous rectification with inhibit, 1.5 A, 1.5 MHz adjustable, step-down switching regulator, 6-Pin DFN; Manufacturer: STMicroelectronics; Accuracy: 2%; Efficiency: 90%; Frequency: 1.5MHz; Max Output current: 1.5A; Max Supply Voltage: 6V; Min Input Voltage: 2.7V; Number of Outputs: 1; Output Type: Fixed,Adjustable; Output Voltage: 3.3V; Polarity: Positive; Quiescent Current: 1.5mA; Synchronous Rectifier: Yes; Case/Package: DFN; Category: Voltage Regulators - Switching; Component Kind: Standard; ComponentLink1Description: Manufacturer URL; ComponentLink1URL: http://www.st.com; ComponentLink2Description: Datasheet; ComponentLink2URL: http://www.st.com/internet/com/TECHNICAL_RESOURCES/TECHNICAL_LITERATURE/DATASHEET/CD00100211.pdf; ComponentLink3Description: https://datasheet.ciiva.com/15113/getdatasheetpartid-188384-15113793.pdf?src-supplier=Verical; ComponentLink3URL: https://datasheet.ciiva.com/15113/getdatasheetpartid-188384-15113793.pdf?src-supplier=Verical; ComponentLink4Description: https://datasheet.ciiva.com/2029/cd00100211-2029328.pdf?src-supplier=Digikey; ComponentLink4URL: https://datasheet.ciiva.com/2029/cd00100211-2029328.pdf?src-supplier=Digikey; ComponentLink5Description: https://datasheet.ciiva.com/2029/cd00100211-2029329.pdf?src-supplier=Digikey; ComponentLink5URL: https://datasheet.ciiva.com/2029/cd00100211-2029329.pdf?src-supplier=Digikey; ComponentLink6Description: https://datasheet.ciiva.com/2029/cd00100211-2029330.pdf?src-supplier=Digikey; ComponentLink6URL: https://datasheet.ciiva.com/2029/cd00100211-2029330.pdf?src-supplier=Digikey; ComponentLink7Description: https://datasheet.ciiva.com/16489/cd00100211-16489901.pdf?src-supplier=Future+Electronics; ComponentLink7URL: https://datasheet.ciiva.com/16489/cd00100211-16489901.pdf?src-supplier=Future+Electronics; ComponentLink8Description: https://datasheet.ciiva.com/4905/cd00100211-4905371.pdf?src-supplier=Mouser; ComponentLink8URL: https://datasheet.ciiva.com/4905/cd00100211-4905371.pdf?src-supplier=Mouser; DatasheetVersion: May-2009; Height: 0.95mm; Lead Free: Lead Free; Length: 3.1mm; Library Name: Altium Content Vault; Manufacturer Part Number: ST1S06PU33R; Max Operating Temperature: 125°C; Min Operating Temperature: -30°C; Mounting Technology: Surface Mount; Operating Supply Voltage: 6V; Output Current: 1.5A; Package Quantity: 1; PackageDescription: 6-Pin Plastic, Dual LLP, 3 x 3 mm Body, 0.95 mm Pitch, Exposed Pad; PackageReference: DFN6D; PackageVersion: 2008; Packaging: Tape and Reel; Pins: 6; Pricing: 1=1.46, 10=1.306, 25=1.2392, 100=1.0179, 250=0.95156, 500=0.8409, 1000=0.66387 (USD); Radiation Hardening: No; RoHS: Yes; RoHS Compliant: Yes; Series: -; Stock: 5274; Supplier: Digikey; Supplier Part Number: 497-6311-1-ND; Switching Frequency: 1.5MHz; Weight: 0.000744oz; Width: 3.1mm
U2 = IC_AX88179B: Component Kind: Standard; Manufacturer Part Number: AX88179B; Library Name: LotusSound.IntLib
Y1 = CMP-27762-007871-1: Crystal 20MHz ±10ppm 10pF SMD-4 5mm x 3.2mm; Manufacturer: Abracon; ESR (Equivalent Series Resistance): 50R; Case/Package: CSMD; Category: Crystals; Component Kind: Standard; ComponentLink1Description: https://datasheet.ciiva.com/15116/getdatasheetpartid-719810-15116718.pdf?src-supplier=Verical; ComponentLink1URL: https://datasheet.ciiva.com/15116/getdatasheetpartid-719810-15116718.pdf?src-supplier=Verical; ComponentLink2Description: https://datasheet.ciiva.com/4944/abm3b-39910-4944991.pdf?src-supplier=Mouser; ComponentLink2URL: https://datasheet.ciiva.com/4944/abm3b-39910-4944991.pdf?src-supplier=Mouser; ComponentLink3Description: https://datasheet.ciiva.com/858/abm3b-858647.pdf?src-supplier=Digikey; ComponentLink3URL: https://datasheet.ciiva.com/858/abm3b-858647.pdf?src-supplier=Digikey; ComponentLink4Description: https://datasheet.ciiva.com/858/abm3b-858648.pdf?src-supplier=Digikey; ComponentLink4URL: https://datasheet.ciiva.com/858/abm3b-858648.pdf?src-supplier=Digikey; ComponentLink5Description: https://datasheet.ciiva.com/858/abm3b-858649.pdf?src-supplier=Digikey; ComponentLink5URL: https://datasheet.ciiva.com/858/abm3b-858649.pdf?src-supplier=Digikey; ComponentLink6Description: https://datasheet.ciiva.com/3175/abm3b-3175832.pdf?src-supplier=Avnet; ComponentLink6URL: https://datasheet.ciiva.com/3175/abm3b-3175832.pdf?src-supplier=Avnet; Depth: 3.2mm; Frequency: 20MHz; Frequency Stability: 10ppm; Frequency Tolerance: 10ppm; Height: 0.043inch; Lead Free: Lead Free; Length: 5mm; Library Name: Altium Content Vault; Load Capacitance: 10pF; Manufacturer Part Number: ABM3B-20.000MHZ-10-1-U-T; Max Operating Temperature: 60°C; Min Operating Temperature: -10°C; Mount: Surface Mount; Mounting Technology: Surface Mount; Number of Pins: 4; Operating Frequency: 20 MHz; Package Quantity: 1000; Packaging: Tape and Reel; Pins: 4; Pricing: 1=0.92, 10=0.813, 50=0.7602, 100=0.6718, 500=0.63642 (USD); REACH SVHC: No SVHC; Reach SVHC Compliant: No; Resistor Type: Ceramic; RoHS: Yes; RoHS Compliant: Yes; Series: ABM3B; Stock: 52539; Supplier: Digikey; Supplier Part Number: 300-8214-1-ND; Termination: SMD/SMT; Tolerance: 10ppm; Width: 0.126inch
